# Altium schematic sheet board_schematic.SchDoc
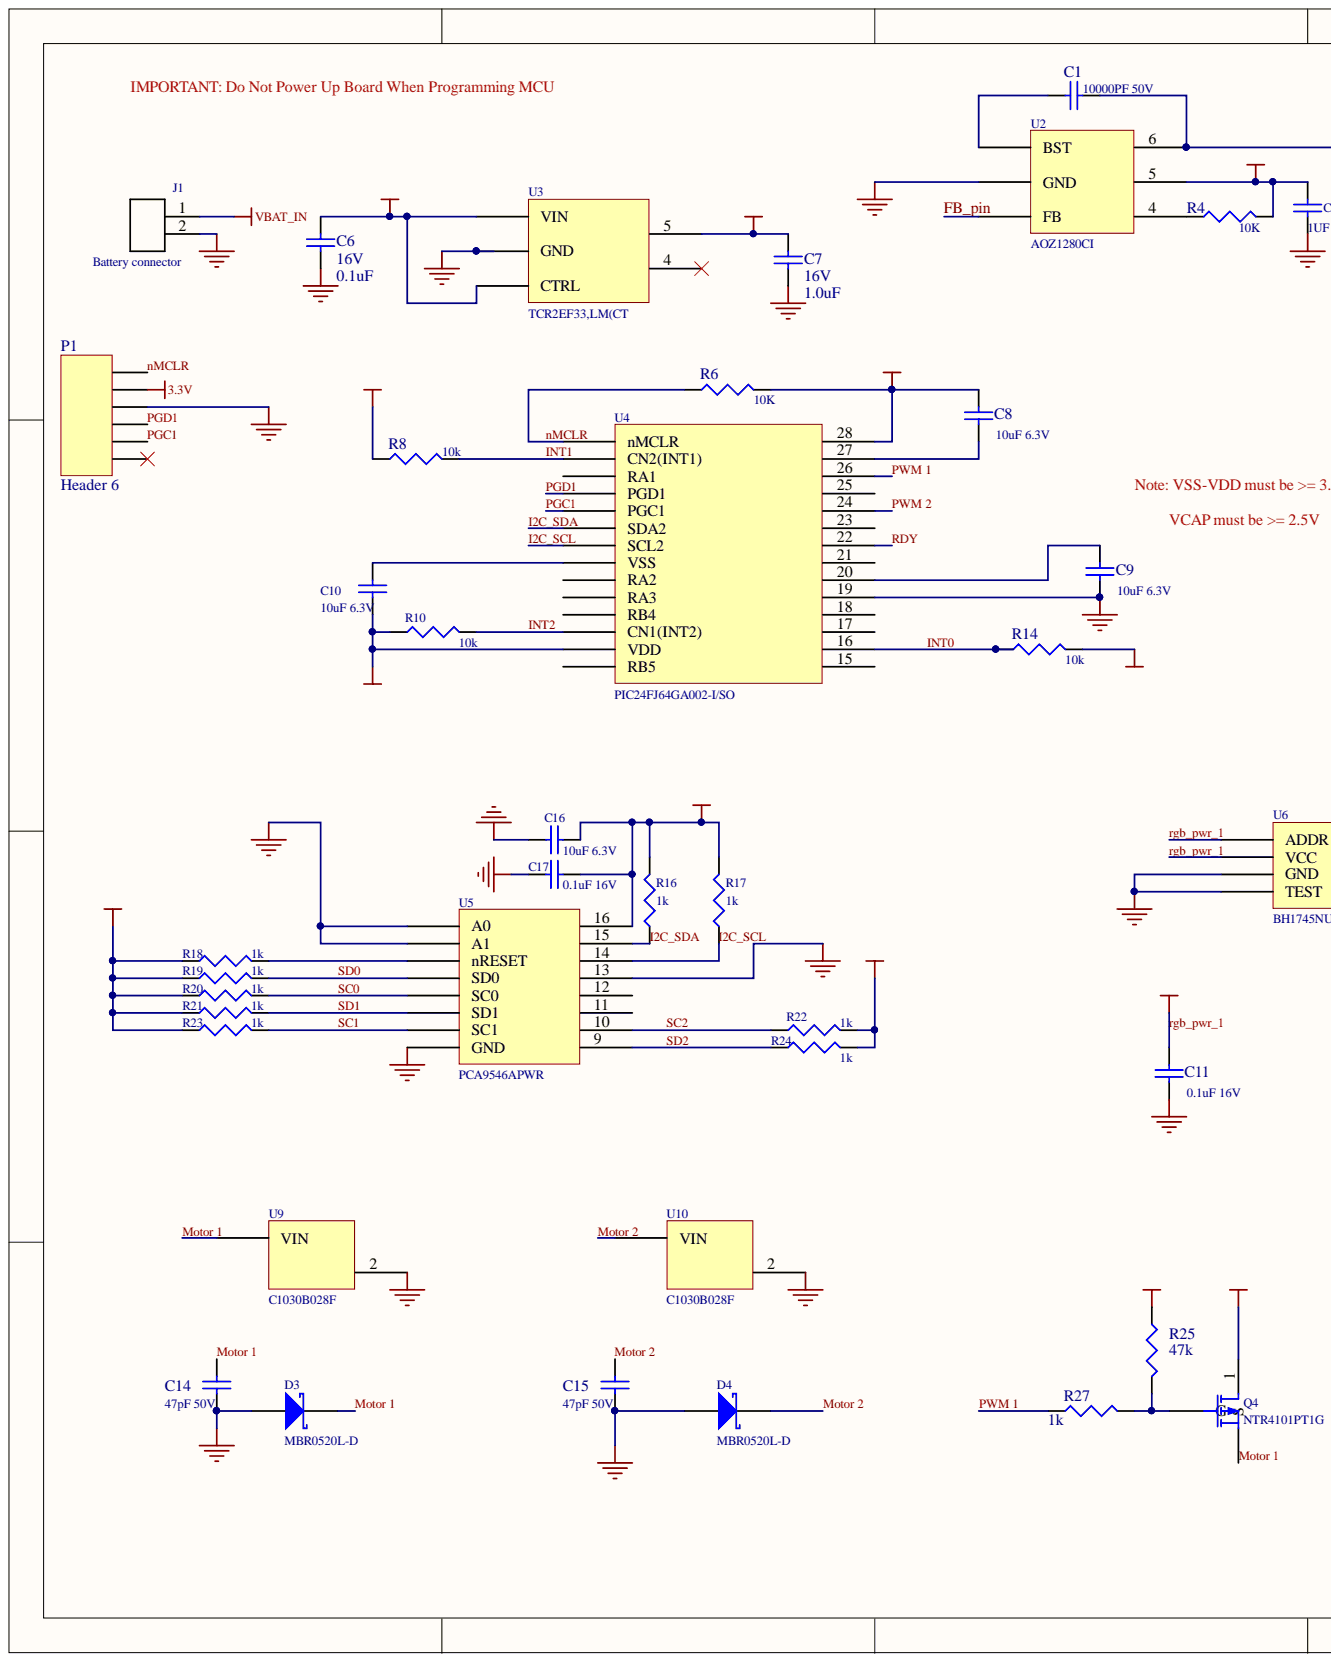
[diagram p0: board_schematic.SchDoc, left]
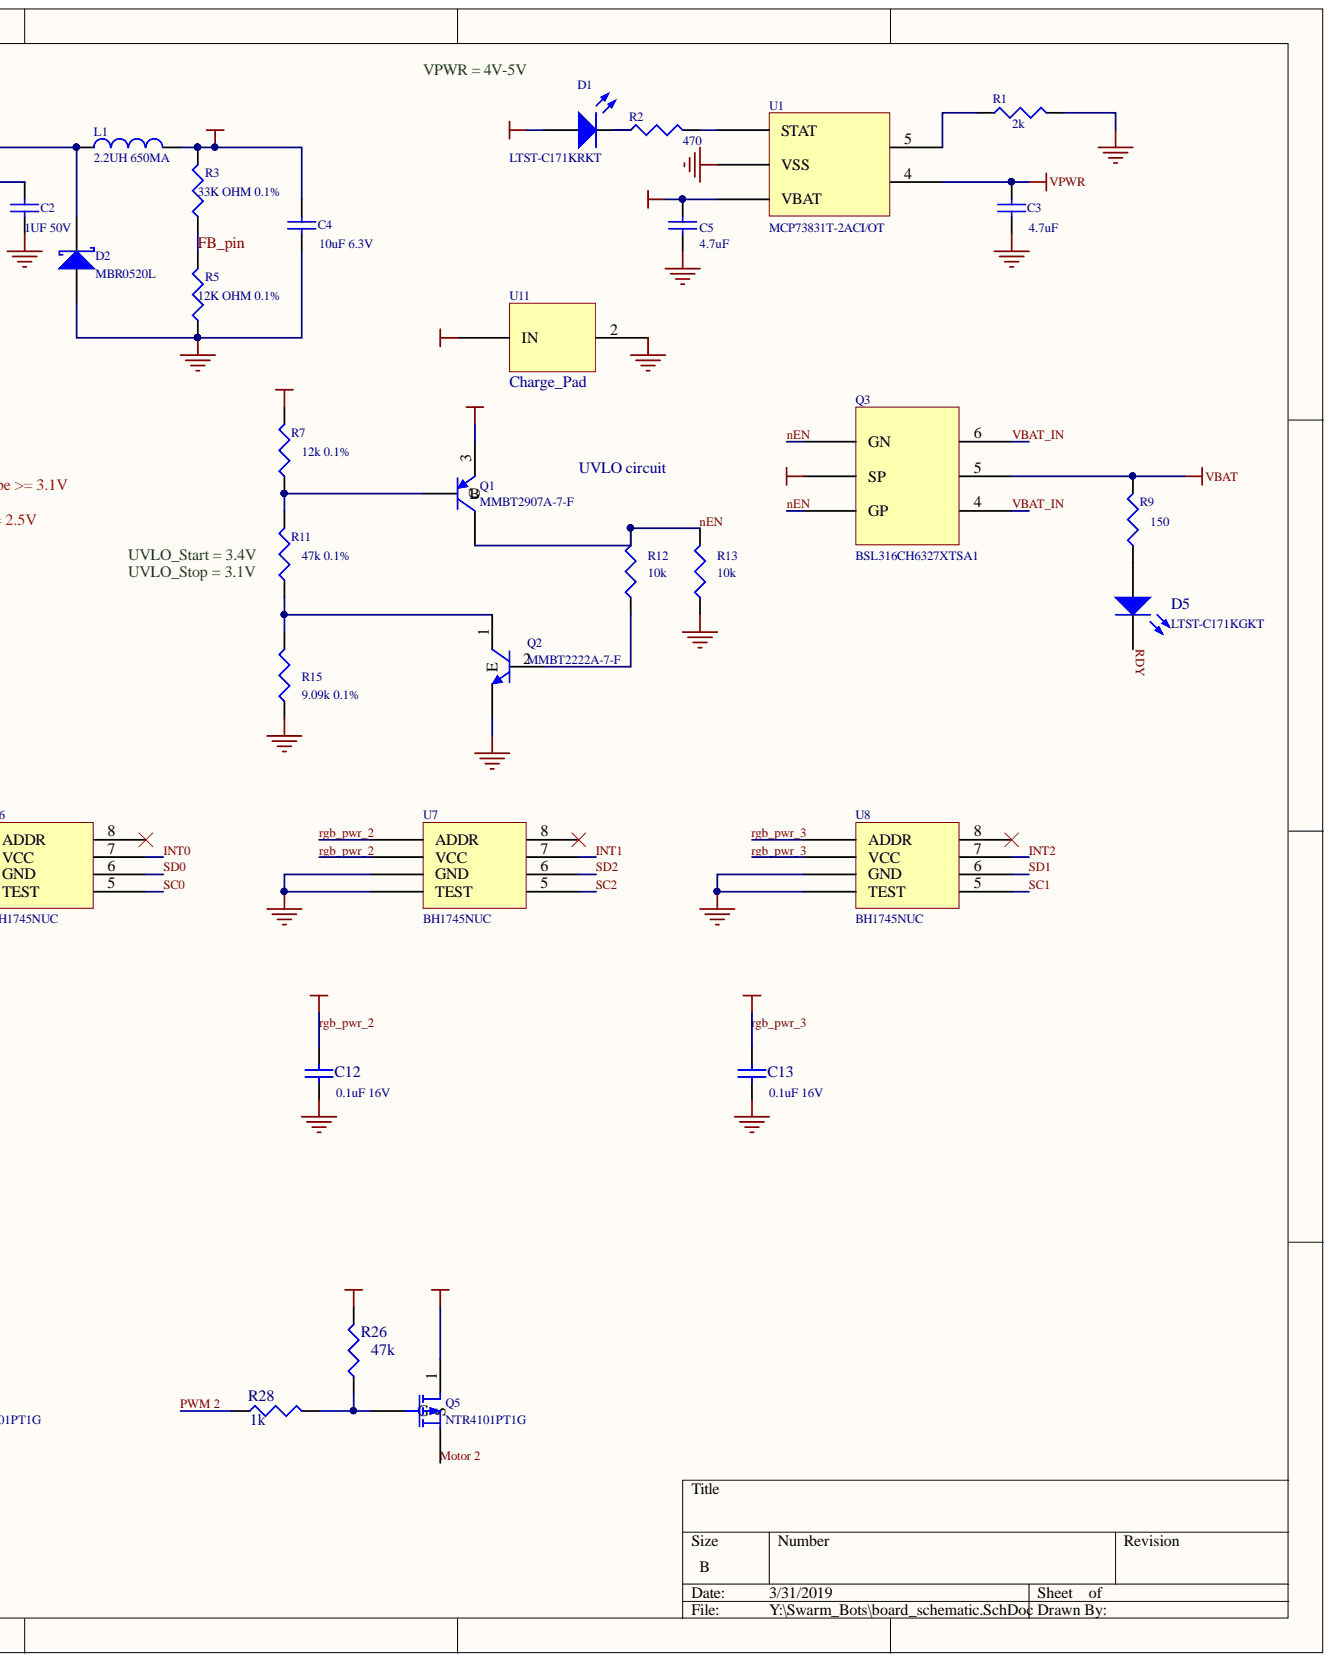
[diagram p1: board_schematic.SchDoc, right]

## Parts
C1  value 10000PF 50V  [1608[0603]]  (hidden comment: Cap Semi)
C2  value 1UF 50V  [1608[0603]]  (hidden comment: Cap Semi)
C3  value 4.7uF  [1608[0603]]  (hidden comment: Cap Semi)
C4  value 10uF 6.3V  [1608[0603]]  (hidden comment: Cap Semi)
C5  value 4.7uF  [1608[0603]]  (hidden comment: Cap Semi)
C6  16V  value 0.1uF  [1608[0603]]
C7  16V  value 1.0uF  [1608[0603]]
C8  value 10uF 6.3V  [1608[0603]]  (hidden comment: Cap Semi)
C9  value 10uF 6.3V  [1608[0603]]  (hidden comment: Cap Semi)
C10  value 10uF 6.3V  [1608[0603]]  (hidden comment: Cap Semi)
C11  value 0.1uF 16V  [1608[0603]]  (hidden comment: Cap Semi)
C12  value 0.1uF 16V  [1608[0603]]  (hidden comment: Cap Semi)
C13  value 0.1uF 16V  [1608[0603]]  (hidden comment: Cap Semi)
C14  value 47pF 50V  [1608[0603]]  (hidden comment: Cap Semi)
C15  value 47pF 50V  [1608[0603]]  (hidden comment: Cap Semi)
C16  value 10uF 6.3V  [1608[0603]]  (hidden comment: Cap Semi)
C17  value 0.1uF 16V  [1608[0603]]  (hidden comment: Cap Semi)
D1  LTST-C171KRKT  [LED_pcb]
D2  MBR0520L  [SMB]
D3  MBR0520L-D  [SODFL375X128-2N]
D4  MBR0520L-D  [SODFL375X128-2N]
D5  LTST-C171KGKT  [LED_pcb]
J1  Battery connector  [JST_S2B-PH-K-S(LF)(SN)]
L1  value 2.2UH 650MA  [0603]  (hidden comment: l)
P1  Header 6  [HDR1X6]
Q1  MMBT2907A-7-F  [SOT90P240X110-3N]
Q2  MMBT2222A-7-F  [SOT90P240X110-3N]
Q3  BSL316CH6327XTSA1  [TSOP95P250X110-6N]
Q4  NTR4101PT1G  [SOT95P290X111-3N]
Q5  NTR4101PT1G  [SOT95P290X111-3N]
R1  value 2k  [J1-0603]  (hidden comment: Res3)
R2  value 470  [J1-0603]  (hidden comment: Res3)
R3  value 33K OHM 0.1%  [J1-0603]  (hidden comment: Res3)
R4  value 10K  [J1-0603]  (hidden comment: Res3)
R5  value 12K OHM 0.1%  [J1-0603]  (hidden comment: Res3)
R6  value 10K  [J1-0603]  (hidden comment: Res3)
R7  value 12k 0.1%  [J1-0603]  (hidden comment: Res3)
R8  value 10k  [J1-0603]  (hidden comment: Res3)
R9  value 150  [J1-0603]  (hidden comment: Res3)
R10  value 10k  [J1-0603]  (hidden comment: Res3)
R11  value 47k 0.1%  [J1-0603]  (hidden comment: Res3)
R12  value 10k  [J1-0603]  (hidden comment: Res3)
R13  value 10k  [J1-0603]  (hidden comment: Res3)
R14  value 10k  [J1-0603]  (hidden comment: Res3)
R15  value 9.09k 0.1%  [J1-0603]  (hidden comment: Res3)
R16  1k  [J1-0603]
R17  1k  [J1-0603]
R18  1k  [J1-0603]
R19  1k  [J1-0603]
R20  1k  [J1-0603]
R21  value 1k  [J1-0603]  (hidden comment: Res3)
R22  value 1k  [J1-0603]  (hidden comment: Res3)
R23  value 1k  [J1-0603]  (hidden comment: Res3)
R24  value 1k  [J1-0603]  (hidden comment: Res3)
R25  value 47k  [J1-0603]  (hidden comment: Res3)
R26  value 47k  [J1-0603]  (hidden comment: Res3)
R27  value 1k  [J1-0603]  (hidden comment: Res3)
R28  value 1k  [J1-0603]  (hidden comment: Res3)
U1  MCP73831T-2ACI/OT  [SOT95P270X145-5N]
U2  AOZ1280CI  [SOT95P280X125-6N]
U3  TCR2EF33,LM(CT  [SOT95P275X111-5N]
U4  PIC24FJ64GA002-I/SO  [SOIC127P1030X265-28N]  (value not drawn)
U5  PCA9546APWR  [PCA9546APWR]
U6  BH1745NUC  [*UDFN-8]
U7  BH1745NUC  [*UDFN-8]
U8  BH1745NUC  [*UDFN-8]
U9  C1030B028F  [PCBComponent_1]
U10  C1030B028F  [PCBComponent_1]
U11  Charge_Pad  [charge_pad]

## Nets
3.0V: C4.2 L1.2 Q4.1 Q5.1 R3.2 R25.1 R26.2
GND: C2.2 C3.1 C4.1 C5.1 C6.1 C7.1 C9.1 C11.2 C12.1 C13.1 C14.1 C15.1 C16.1 C17.1 D2.1 D3.1 D4.1 J1.2 P1.4 Q2.3 R1.2 R5.1 R13.1 R15.1 U1.2(VSS) U2.2(GND) U3.2(GND) U4.19 U5.1(A0) U5.2(A1) U5.8(GND) U5.13 U6.3(GND) U6.4(TEST) U7.3(GND) U7.4(TEST) U8.3(GND) U8.4(TEST) U9.2 U10.2 U11.2
VBAT: C6.2 Q3.5 R9.2 U3.1(VIN) U3.3(CTRL)
VBAT_SP: C5.2 Q3.2(SP) U1.3(VBAT)
VPWR: C3.2 D1.1 U1.4 U11.1
FB_pin: R3.1 R5.2 U2.3(FB)
I2C_SCL: R17.1 U4.7(SCL2) U5.14
I2C_SDA: R16.1 U4.6(SDA2) U5.15
INT0: R14.1 U4.16 U6.7
INT1: R8.2 U4.2(CN2(INT1)) U7.7
INT2: R10.2 U4.12(CN1(INT2)) U8.7
Motor 1: C14.2 D3.2 Q4.3 U9.1
Motor 2: C15.2 D4.2 Q5.3 U10.1
nEN: Q1.1 Q3.1(GN) Q3.3(GP) R12.2 R13.2
nMCLR: P1.6 R6.1 U4.1(nMCLR)
PGC1: P1.2 U4.5(PGC1)
PGD1: P1.3 U4.4(PGD1)
PWM 1: R27.1 U4.26
PWM 2: R28.1 U4.24
RDY: D5.2 U4.22
rgb_pwr_1: C2.1 C7.2 C8.2 C10.1 C11.1 C12.2 C13.2 C16.2 C17.2 P1.5 R4.2 R6.2 R8.1 R10.1 R14.2 R16.2 R17.2 R18.1 R19.1 R20.1 R21.1 R22.2 R23.1 R24.2 U2.5 U3.5 U4.13(VDD) U4.28 U5.16 U6.1(ADDR) U6.2(VCC) U7.1(ADDR) U7.2(VCC) U8.1(ADDR) U8.2(VCC)
SC0: R20.2 U5.5(SC0) U6.5
SC1: R23.2 U5.7(SC1) U8.5
SC2: R22.1 U5.10 U7.5
SD0: R19.2 U5.4(SD0) U6.6
SD1: R21.2 U5.6(SD1) U8.6
SD2: R24.1 U5.9 U7.6
VBAT_IN: J1.1 Q1.3 Q3.4 Q3.6 R7.2
NetC1_1: C1.1 U2.1(BST)
NetC1_2: C1.2 D2.2 L1.1 U2.6
NetC8_1: C8.1 U4.27
NetC9_2: C9.2 U4.20
NetC10_2: C10.2 U4.8(VSS)
NetD1_2: D1.2 R2.1
NetD5_1: D5.1 R9.1
NetQ1_2: Q1.2 R7.1 R11.2
NetQ2_1: Q2.1 R11.1 R15.2
NetQ2_2: Q2.2 R12.1
NetQ4_2: Q4.2 R25.2 R27.2
NetQ5_2: Q5.2 R26.1 R28.2
NetR1_1: R1.1 U1.5
NetR2_2: R2.2 U1.1(STAT)
NetR4_1: R4.1 U2.4
NetR18_2: R18.2 U5.3(nRESET)

Not connected: P1 1; U3 4; U4 3(RA1) 9(RA2) 10(RA3) 11(RB4) 14(RB5) 15 17 18 21 23 25; U5 11 12; U6 8; U7 8; U8 8

## Library data of the parts
C1 C3-C17 = Cap Semi: Capacitor (Semiconductor SIM Model); Component Kind: Standard; LatestRevisionDate: 29-May-2009; LatestRevisionNote: IPC-7351 Footprint Added.; Library Name: Miscellaneous Devices.IntLib; PackageDescription: Chip Capacitor; PackageReference: C1206; Published: 8-Jun-2000; Signal Integrity: Cap Semi; Simulation: CAP; Publisher: Altium Limited
C2 = Cap Semi: Capacitor (Semiconductor SIM Model); Value: 1UF 50V; Component Kind: Standard; LatestRevisionDate: 29-May-2009; LatestRevisionNote: IPC-7351 Footprint Added.; Library Name: Miscellaneous Devices.IntLib; PackageDescription: Chip Capacitor; PackageReference: C1206; Published: 8-Jun-2000; Signal Integrity: Cap Semi; Simulation: CAP; Publisher: Altium Limited
D1 D5 = LED2: Typical RED, GREEN, YELLOW, AMBER GaAs LED; Component Kind: Standard; LatestRevisionDate: 17-Jul-2002; LatestRevisionNote: Re-released for DXP Platform.; Library Name: Miscellaneous Devices.IntLib; PackageDescription: SM LED; 2 Flat Leads; PackageReference: 3.2X1.6X1.1; PackageVersion: Feb-2002; Published: 8-Jun-2000; Simulation: LED2; Publisher: Altium Limited
D2-D4 = D Schottky: Schottky Diode; Code_JEDEC: DO-214-AA; Component Kind: Standard; LatestRevisionDate: 17-Jul-2002; LatestRevisionNote: Re-released for DXP Platform.; Library Name: Miscellaneous Devices.IntLib; PackageDescription: DO-214-AA/SMB; 2 C-Bend Leads; Body 5.3 x 3.6 mm, inc. leads (LxW); PackageReference: SMB; Published: 8-Jun-2000; Signal Integrity: D Schottky; Simulation: SKYDIODE; Publisher: Altium Limited
J1 = S2B-PH-K-S(LF)(SN): PH Series 2 Position 2.0 mm Through Hole Side Entry Shrouded Header; MF: JST Sales; MP: S2B-PH-K-S_LF__SN_; Component Kind: Standard; Digi-Key_Part_Number: 455-1719-ND; Digi-Key_Purchase_URL: https://www.digikey.com/product-detail/en/jst-sales-america-inc/S2B-PH-K-S_LF__SN_/455-1719-ND/926626?utm_source=snapeda&utm_medium=aggregator&utm_campaign=symbol; Library Name: S2B-PH-K-S_LF__SN_.SchLib; Package: None; SnapEDA_Link: https://www.snapeda.com/parts/S2B-PH-K-S%28LF%29%28SN%29/JST%20Sales%20America%20Inc./view-part/?ref=snap
L1 = Inductor: Code_IEC: 1005; Code_JEITA: 0402; Component Kind: Standard; Description: Inductor; LatestRevisionDate: 29-May-2009; LatestRevisionNote: IPC-7351 Footprint Added.; Library Name: Miscellaneous Devices.IntLib; PackageDescription: Chip Inductor; PackageReference: 0402-A; Published: 8-Jun-2000; Signal Integrity: Inductor; Simulation: INDUCTOR; Publisher: Altium Limited
P1 = Header 6: Header, 6-Pin; Component Kind: Standard; LatestRevisionDate: 17-Jul-2002; LatestRevisionNote: Re-released for DXP Platform.; Library Name: Miscellaneous Connectors.IntLib; Signal Integrity: Connector; Publisher: Altium Limited
Q1 = PNP: PNP Bipolar Transistor; Component Kind: Standard; LatestRevisionDate: 29-May-2009; LatestRevisionNote: IPC-7351 Footprint Added.; Library Name: Miscellaneous Devices.IntLib; PackageDescription: 3-Pin SOT-23 Package 0.95 mm Pitch, 2.4 mm Lead Span; PackageReference: SOT-23B; PackageVersion: Aug-1999; Published: 8-Jun-2000; Signal Integrity: PNP; Simulation: PNP; Publisher: Altium Limited
Q2 = NPN: NPN Bipolar Transistor; Code_JEDEC: TO-226-AA; Component Kind: Standard; LatestRevisionDate: 17-Jul-2002; LatestRevisionNote: Re-released for DXP Platform.; Library Name: Miscellaneous Devices.IntLib; PackageDescription: TO, Flat Index; 3 In-Line, Axial Leads; Body Dia. 4.8mm; Leads 0.55 x 0.51 mm (max); PackageReference: TO-226-AA; Published: 8-Jun-2000; Signal Integrity: NPN; Simulation: NPN; Publisher: Altium Limited
Q3 U1-U11 = Component_1: Component Kind: Standard
  Q3: Library Name: mos_inverter.SchLib
  U2: Library Name: Buck_converter.SchLib
  U3: Library Name: LDO_reg.SchLib
  U4: Library Name: PIC24F_MCU.SchLib
  U5: Library Name: I2CBus.SchLib
  U6-U8: Library Name: rgb_sensor.SchLib
  U9 U10: Library Name: motor_pads.SchLib
  U11: Library Name: charge_pad.SchLib
  U1: Library Name: batt_charger.SchLib
Q4 Q5 = MOSFET-P: P-Channel MOSFET; Component Kind: Standard; LatestRevisionDate: 17-Jul-2002; LatestRevisionNote: Re-released for DXP Platform.; Library Name: Miscellaneous Devices.IntLib; PackageDescription: TO, Flat Index; 3 In-Line, Axial Leads; Body Dia. 4.6mm; Lead Dia. 0.5 mm (max); PackageReference: E3; PackageVersion: 1995/1996; Published: 8-Jun-2000; Simulation: PMOS; Publisher: Altium Limited
R1 R2 R4-R28 = Res3: Resistor; Component Kind: Standard; LatestRevisionDate: 29-May-2009; LatestRevisionNote: IPC-7351 Footprint Added.; Library Name: Miscellaneous Devices.IntLib; PackageDescription: Chip Resistor; PackageReference: J1-0603; Published: 8-Jun-2000; Signal Integrity: Res; Simulation: RESISTOR; Publisher: Altium Limited
  R16-R20: Value: 1K
R3 = Res3: Resistor; Value: 33K OHM 0.1%; Component Kind: Standard; LatestRevisionDate: 29-May-2009; LatestRevisionNote: IPC-7351 Footprint Added.; Library Name: Miscellaneous Devices.IntLib; PackageDescription: Chip Resistor; PackageReference: J1-0603; Published: 8-Jun-2000; Signal Integrity: Res; Simulation: RESISTOR; Publisher: Altium Limited
